# Altium schematic sheet DC_MODULE_TOP.Sch
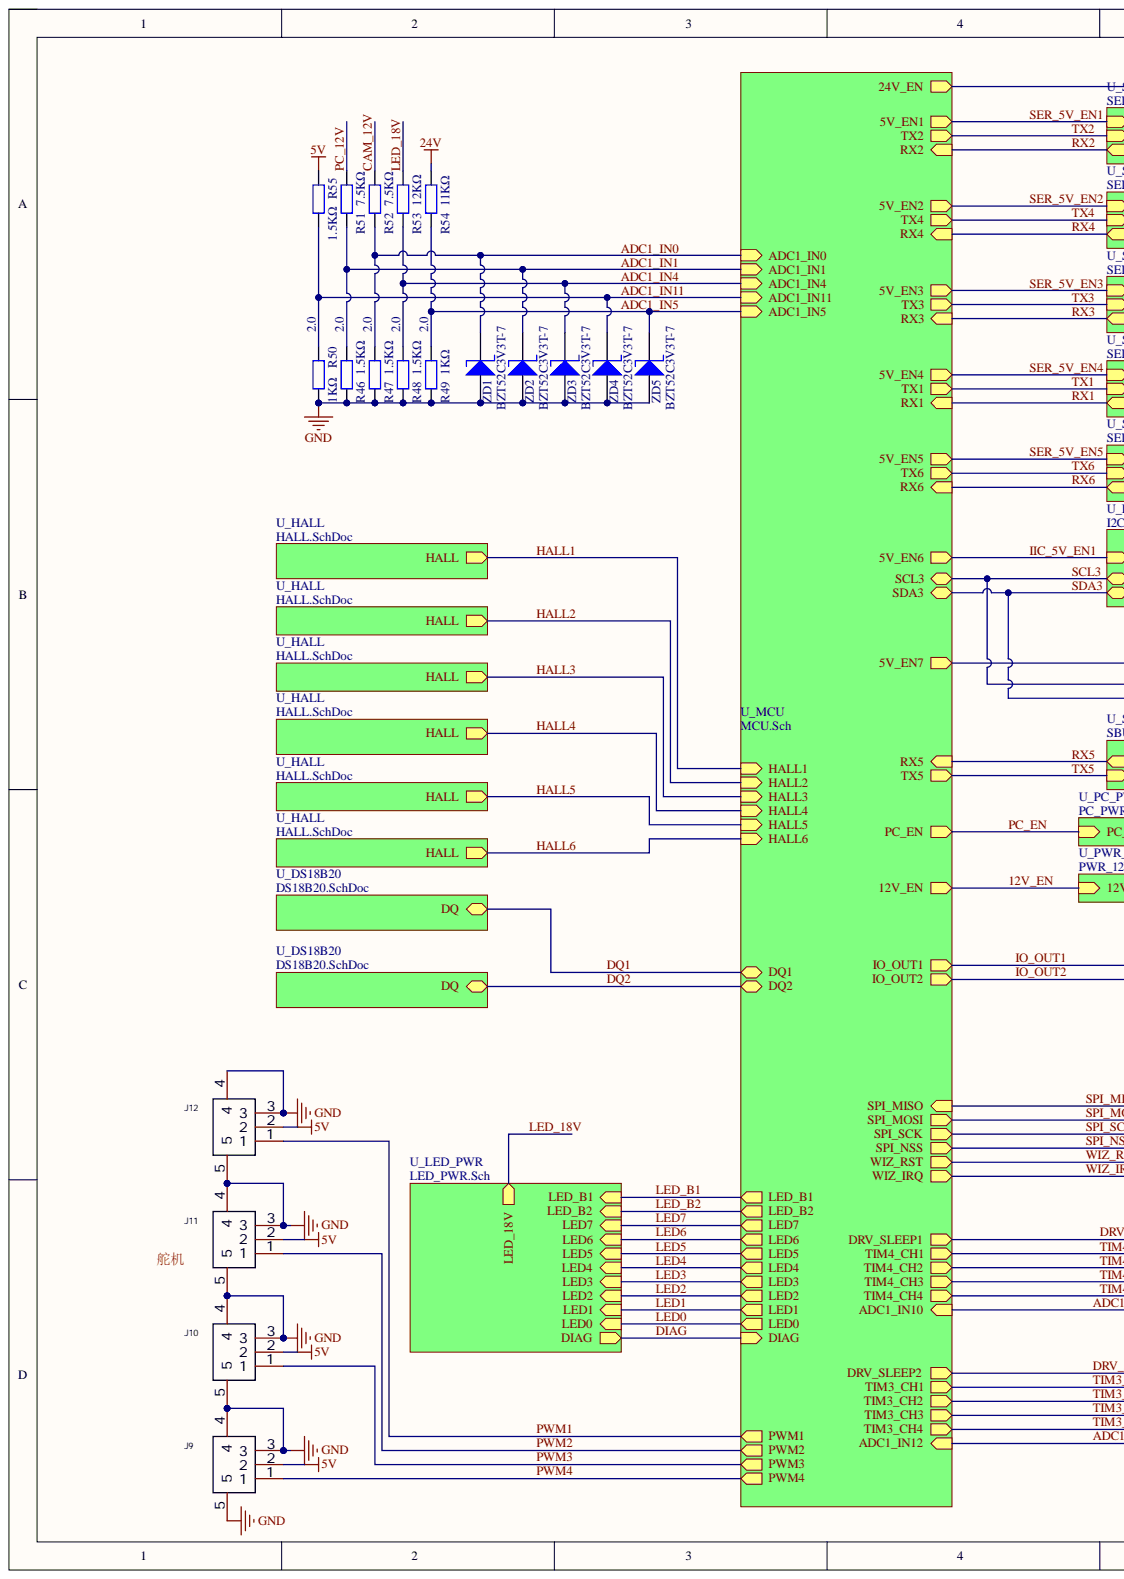
[diagram p0: DC_MODULE_TOP.Sch, left]
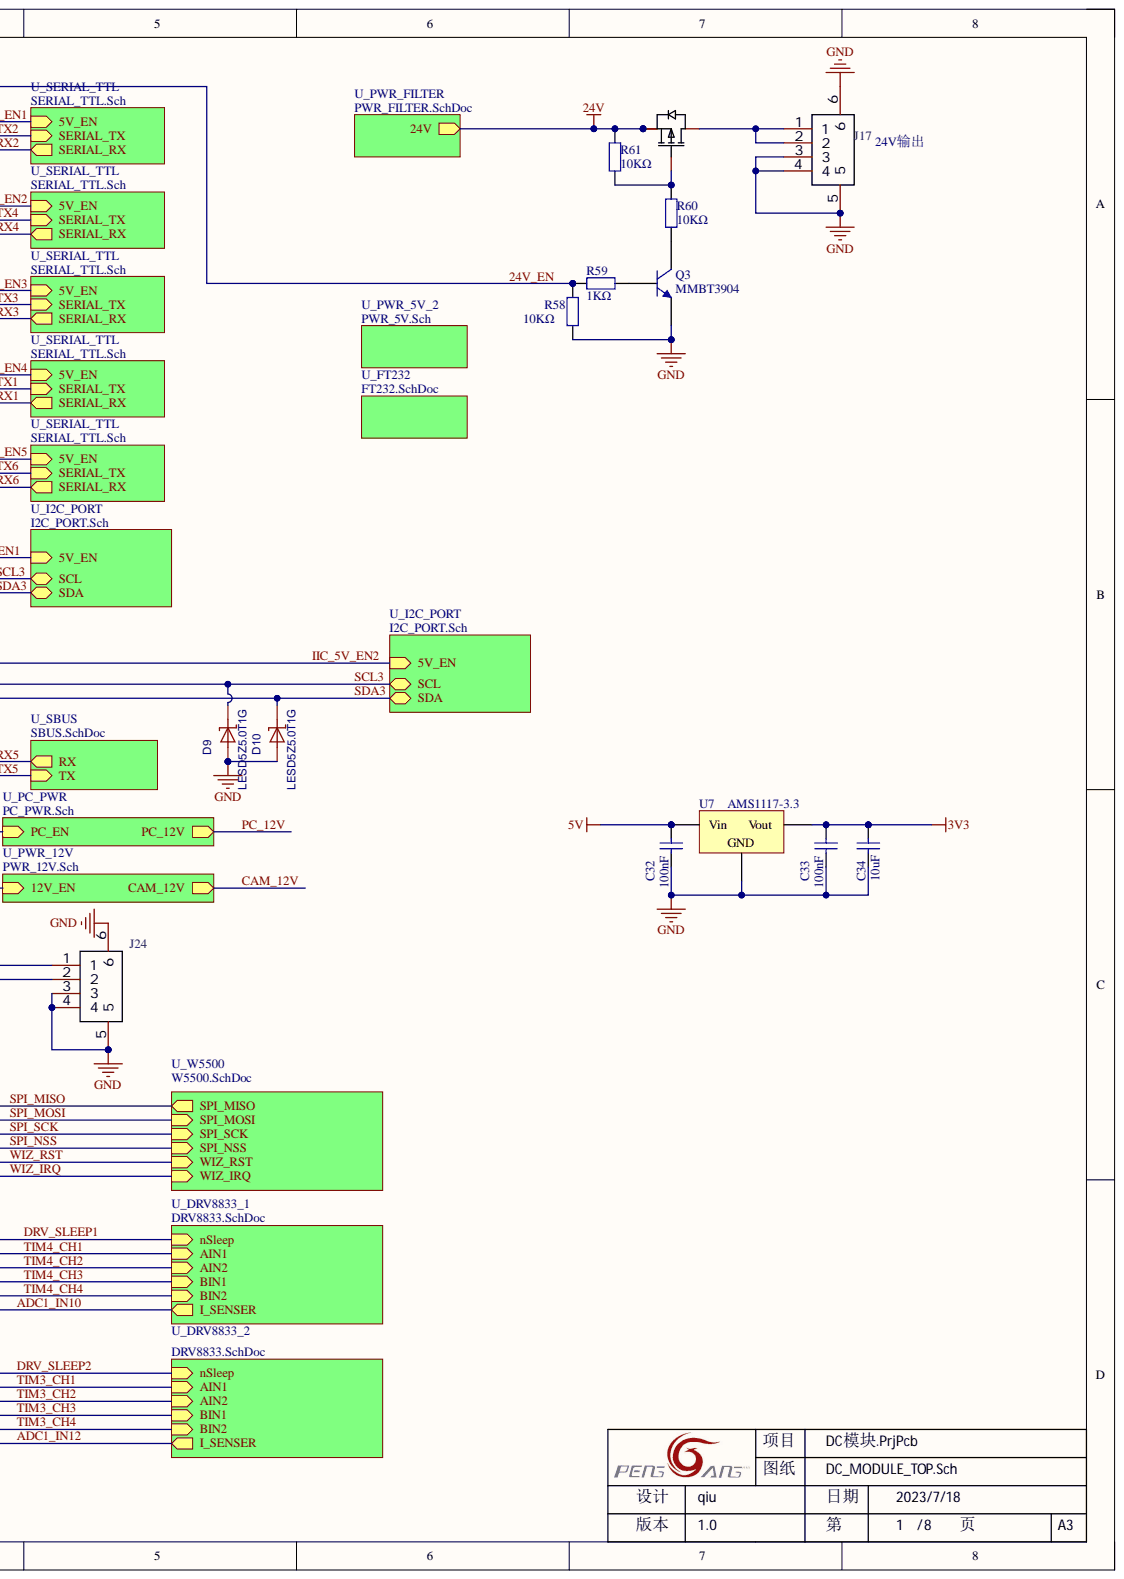
[diagram p1: DC_MODULE_TOP.Sch, right]

## Parts
C32  100nF  [0402_C]
C33  100nF  [0402_C]
C34  10uF  [0402_C]
D9  LESD5Z5.0T1G  [SOD-523_L1.2-W0.8-LS1.6-RD]
D10  LESD5Z5.0T1G  [SOD-523_L1.2-W0.8-LS1.6-RD]
J9  SM03B-GHS-TB(LF)(SN)  [CONN-SMD_SM03B-GHS-TB-LF-SN]  (value not drawn)
J10  SM03B-GHS-TB(LF)(SN)  [CONN-SMD_SM03B-GHS-TB-LF-SN]  (value not drawn)
J11  SM03B-GHS-TB(LF)(SN)  [CONN-SMD_SM03B-GHS-TB-LF-SN]  (value not drawn)
J12  SM03B-GHS-TB(LF)(SN)  [CONN-SMD_SM03B-GHS-TB-LF-SN]  (value not drawn)
J17  SM04B-GHS-TB(LF)(SN)  [CONN-SMD_4P-P1.25_SM04B-GHS-TB-LF-SN]  (value not drawn)
J24  SM04B-GHS-TB(LF)(SN)  [CONN-SMD_4P-P1.25_SM04B-GHS-TB-LF-SN]  (value not drawn)
Q3  MMBT3904  [SOT-23(SOT-23-3)]
Q4  HSBB6115  [DFN-8_L3.0-W3.0-P0.65-BL-1]  (value not drawn)
R46  1.5KΩ  [0402_R]
R47  1.5KΩ  [0402_R]
R48  1.5KΩ  [0402_R]
R49  1KΩ  [0402_R]
R50  1KΩ  [0402_R]
R51  7.5KΩ  [0402_R]
R52  7.5KΩ  [0402_R]
R53  12KΩ  [0402_R]
R54  11KΩ  [0603_R]
R55  1.5KΩ  [0402_R]
R58  10KΩ  [0402_R]
R59  1KΩ  [0402_R]
R60  10KΩ  [0402_R]
R61  10KΩ  [0402_R]
U7  AMS1117-3.3  [SOT-223]
ZD1  BZT52C3V3T-7  [SOD-523]
ZD2  BZT52C3V3T-7  [SOD-523]
ZD3  BZT52C3V3T-7  [SOD-523]
ZD4  BZT52C3V3T-7  [SOD-523]
ZD5  BZT52C3V3T-7  [SOD-523]

## Nets
3V3: C33.1 C34.2 U7.2
5V: C32.1 J9.2 J10.2 J11.2 J12.2 R55.2 U7.3
24V: Q4.1 Q4.2 Q4.3 R54.2 R61.2
GND: C32.2 C33.2 C34.1 D9.2 D10.2 J9.3 J9.4 J9.5 J10.3 J10.4 J10.5 J11.3 J11.4 J11.5 J12.3 J12.4 J12.5 J17.3 J17.4 J17.5 J17.6 J24.3 J24.4 J24.5 J24.6 Q3.2 R46.1 R47.1 R48.1 R49.1 R50.1 R58.1 U7.1 ZD1.1 ZD2.1 ZD3.1 ZD4.1 ZD5.1
24V_EN: R58.2 R59.1
ADC1_IN0: R47.2 R52.1 ZD1.2
ADC1_IN1: R46.2 R51.1 ZD2.2
ADC1_IN4: R48.2 R53.1 ZD3.2
ADC1_IN5: R49.2 R54.1 ZD5.2
ADC1_IN11: R50.2 R55.1 ZD4.2
CAM_12V: R52.2
IO_OUT1: J24.1
IO_OUT2: J24.2
LED_18V: R53.2
PC_12V: R51.2
PWM1: J12.1
PWM2: J11.1
PWM3: J10.1
PWM4: J9.1
SCL3: D9.1
SDA3: D10.1
NetJ17_1: J17.1 J17.2 Q4.5
NetQ3_1: Q3.1 R59.2
NetQ3_3: Q3.3 R60.1
NetQ4_4: Q4.4 R60.2 R61.1
12V_EN:
ADC1_IN10:
ADC1_IN12:
DIAG:
DQ1:
DQ2:
DRV_SLEEP1:
DRV_SLEEP2:
HALL1:
HALL2:
HALL3:
HALL4:
HALL5:
HALL6:
IIC_5V_EN1:
IIC_5V_EN2:
LED0:
LED1:
LED2:
LED3:
LED4:
LED5:
LED6:
LED7:
LED_B1:
LED_B2:
PC_EN:
RX1:
RX2:
RX3:
RX4:
RX5:
RX6:
SER_5V_EN1:
SER_5V_EN2:
SER_5V_EN3:
SER_5V_EN4:
SER_5V_EN5:
SPI_MISO:
SPI_MOSI:
SPI_NSS:
SPI_SCK:
TIM3_CH1:
TIM3_CH2:
TIM3_CH3:
TIM3_CH4:
TIM4_CH1:
TIM4_CH2:
TIM4_CH3:
TIM4_CH4:
TX1:
TX2:
TX3:
TX4:
TX5:
TX6:
WIZ_IRQ:
WIZ_RST:

## Library data of the parts
C32 C33 = 0402 100nF (104) 10% 16V: 贴片电容; Component Kind: Standard; ComponentLink1Description: 供应商链接; ComponentLink1URL: http://www.szlcsc.com/product/details_1877.html; Library Name: Miscellaneous Devices LC.IntLib; Package: 0402; Supplier: LC; SuppliersPartNumber: C1525
C34 = 0402 10uF (106) 20% 6.3V: 贴片电容; Component Kind: Standard; ComponentLink1Description: 供应商链接; ComponentLink1URL: http://www.szlcsc.com/product/details_16204.html; Library Name: Miscellaneous Devices LC.IntLib; Package: 0402; Supplier: LC; SuppliersPartNumber: C15525
D9 D10 = ESD-LESD5Z5.0T1G: ESD二极管单向5V; Component Kind: Standard; ComponentLink1Description: 供应商链接; ComponentLink1URL: https://item.szlcsc.com/147485.html; Library Name: mylib.SchLib; SuppliersPartNumber: C136171
J9-J12 = GH_SM03B-GHS-TB(LF)(SN): Manufacturer: JST; Add into BOM: yes; Current Rating (Max): 1A; Gender: 公型插针; Number of PINs Per Row: 3; Pins Structure: 1x3P; Pitch: 1.25mm; Reference Series: GH; Component Kind: Standard; ComponentLink1Description: 供应商链接; ComponentLink1URL: https://item.szlcsc.com/529631.html; Convert to PCB: yes; Datasheet: https://atta.szlcsc.com/upload/public/pdf/source/20200416/C514175_6D761544780BFF1FCAB26BE8A747A99F.pdf; Device: SM03B-GHS-TB(LF)(SN); JLCPCB Part Class: Extended Part; LCSC Part Name: GH 1.25mm 1x3P 卧贴 GH系列; Library Name: mylib.SchLib; Manufacturer Part: SM03B-GHS-TB(LF)(SN); Mounting Style: 卧贴; Name: SM03B-GHS-TB(LF)(SN); Number of Rows: 1; Operating Temperature Range: -25℃~+85℃; Row Spacing: -; Supplier: LCSC; Supplier Footprint: SMD,P=1.25mm; SuppliersPartNumber: C514175; Symbol: SM03B-GHS-TB(LF)(SN)
J17 J24 = GH_SM04B-GHS-TB(LF)(SN): Manufacturer: JST; Add into BOM: yes; Number of PINs Per Row: 4; Pins Structure: 1x4P; Pitch: 1.25mm; Reference Series: GH; Component Kind: Standard; ComponentLink1Description: 供应商链接; ComponentLink1URL: https://item.szlcsc.com/207060.html; Contact Material: 磷青铜; Convert to PCB: yes; Datasheet: https://atta.szlcsc.com/upload/public/pdf/source/20180327/C189895_E152F1273677DB005E39DD42455B7B10.pdf; Device: SM04B-GHS-TB(LF)(SN); JLCPCB Part Class: Extended Part; LCSC Part Name: GH 1.25mm 1x4P; Library Name: mylib.SchLib; Manufacturer Part: SM04B-GHS-TB(LF)(SN); Mounting Style: -; Name: SM04B-GHS-TB(LF)(SN); Number of Rows: 1; Row Spacing: -; Supplier: LCSC; Supplier Footprint: SMD,P=1.25mm; SuppliersPartNumber: C189895; Symbol: SM04B-GHS-TB(LF)(SN)
Q3 = SOT-23 MMBT3904 1AM: 三极管; Component Kind: Standard; ComponentLink1Description: 供应商链接; ComponentLink1URL: https://item.szlcsc.com/21237.html; Library Name: Miscellaneous Devices LC.IntLib; Package: SOT-23; Supplier: LC; SuppliersPartNumber: C20526
Q4 = MOS-HSBB6115: P沟道 60V 26A; Component Kind: Standard; ComponentLink1Description: 供应商链接; ComponentLink1URL: https://item.szlcsc.com/905905.html; Library Name: mylib.SchLib; SuppliersPartNumber: C845604
R46-R48 R55 = 0402 1.5KΩ (1501) 1%: 贴片电阻; Component Kind: Standard; ComponentLink1Description: 供应商链接; ComponentLink1URL: http://www.szlcsc.com/product/details_26610.html; Library Name: Miscellaneous Devices LC.IntLib; Package: 0402; Supplier: LC; SuppliersPartNumber: C25867
R49 R50 R59 = 0402 1KΩ (1001) 1%: 贴片电阻; Component Kind: Standard; ComponentLink1Description: 供应商链接; ComponentLink1URL: http://www.szlcsc.com/product/details_12256.html; Library Name: Miscellaneous Devices LC.IntLib; Package: 0402; Supplier: LC; SuppliersPartNumber: C11702
R51 R52 = 0402 7.5KΩ (7501) 1%: 贴片电阻; Component Kind: Standard; ComponentLink1Description: 供应商链接; ComponentLink1URL: http://www.szlcsc.com/product/details_26661.html; Library Name: Miscellaneous Devices LC.IntLib; Package: 0402; Supplier: LC; SuppliersPartNumber: C25918
R53 = 0402 12KΩ (1202) 1%: 贴片电阻; Component Kind: Standard; ComponentLink1Description: 供应商链接; ComponentLink1URL: http://www.szlcsc.com/product/details_26495.html; Library Name: Miscellaneous Devices LC.IntLib; Package: 0402; Supplier: LC; SuppliersPartNumber: C25752
R54 = 0603 11KΩ (1102) 1%: 贴片电阻; Component Kind: Standard; ComponentLink1Description: 供应商链接; ComponentLink1URL: http://www.szlcsc.com/product/details_26693.html; Library Name: Miscellaneous Devices LC.IntLib; Package: 0603; Supplier: LC; SuppliersPartNumber: C25950
R58 R60 R61 = 0402 10KΩ (1002) 1%: 贴片电阻; Component Kind: Standard; ComponentLink1Description: 供应商链接; ComponentLink1URL: http://www.szlcsc.com/product/details_26487.html; Library Name: Miscellaneous Devices LC.IntLib; Package: 0402; Supplier: LC; SuppliersPartNumber: C25744
U7 = SOT-223 AMS1117-3.3: 低压差线性稳压(LDO); Component Kind: Standard; ComponentLink1Description: 供应商链接; ComponentLink1URL: http://www.szlcsc.com/product/details_6652.html; Library Name: Miscellaneous Devices LC.IntLib; Package: SOT-223; Supplier: LC; SuppliersPartNumber: C6186
ZD1-ZD5 = ZENER-BZT52C3V3T-7: 稳压二极管3.3V; Component Kind: Standard; ComponentLink1Description: 供应商链接; ComponentLink1URL: https://item.szlcsc.com/162903.html; Library Name: mylib.SchLib; SuppliersPartNumber: C151563
